# Altium schematic sheet TestShieldICs.SchDoc
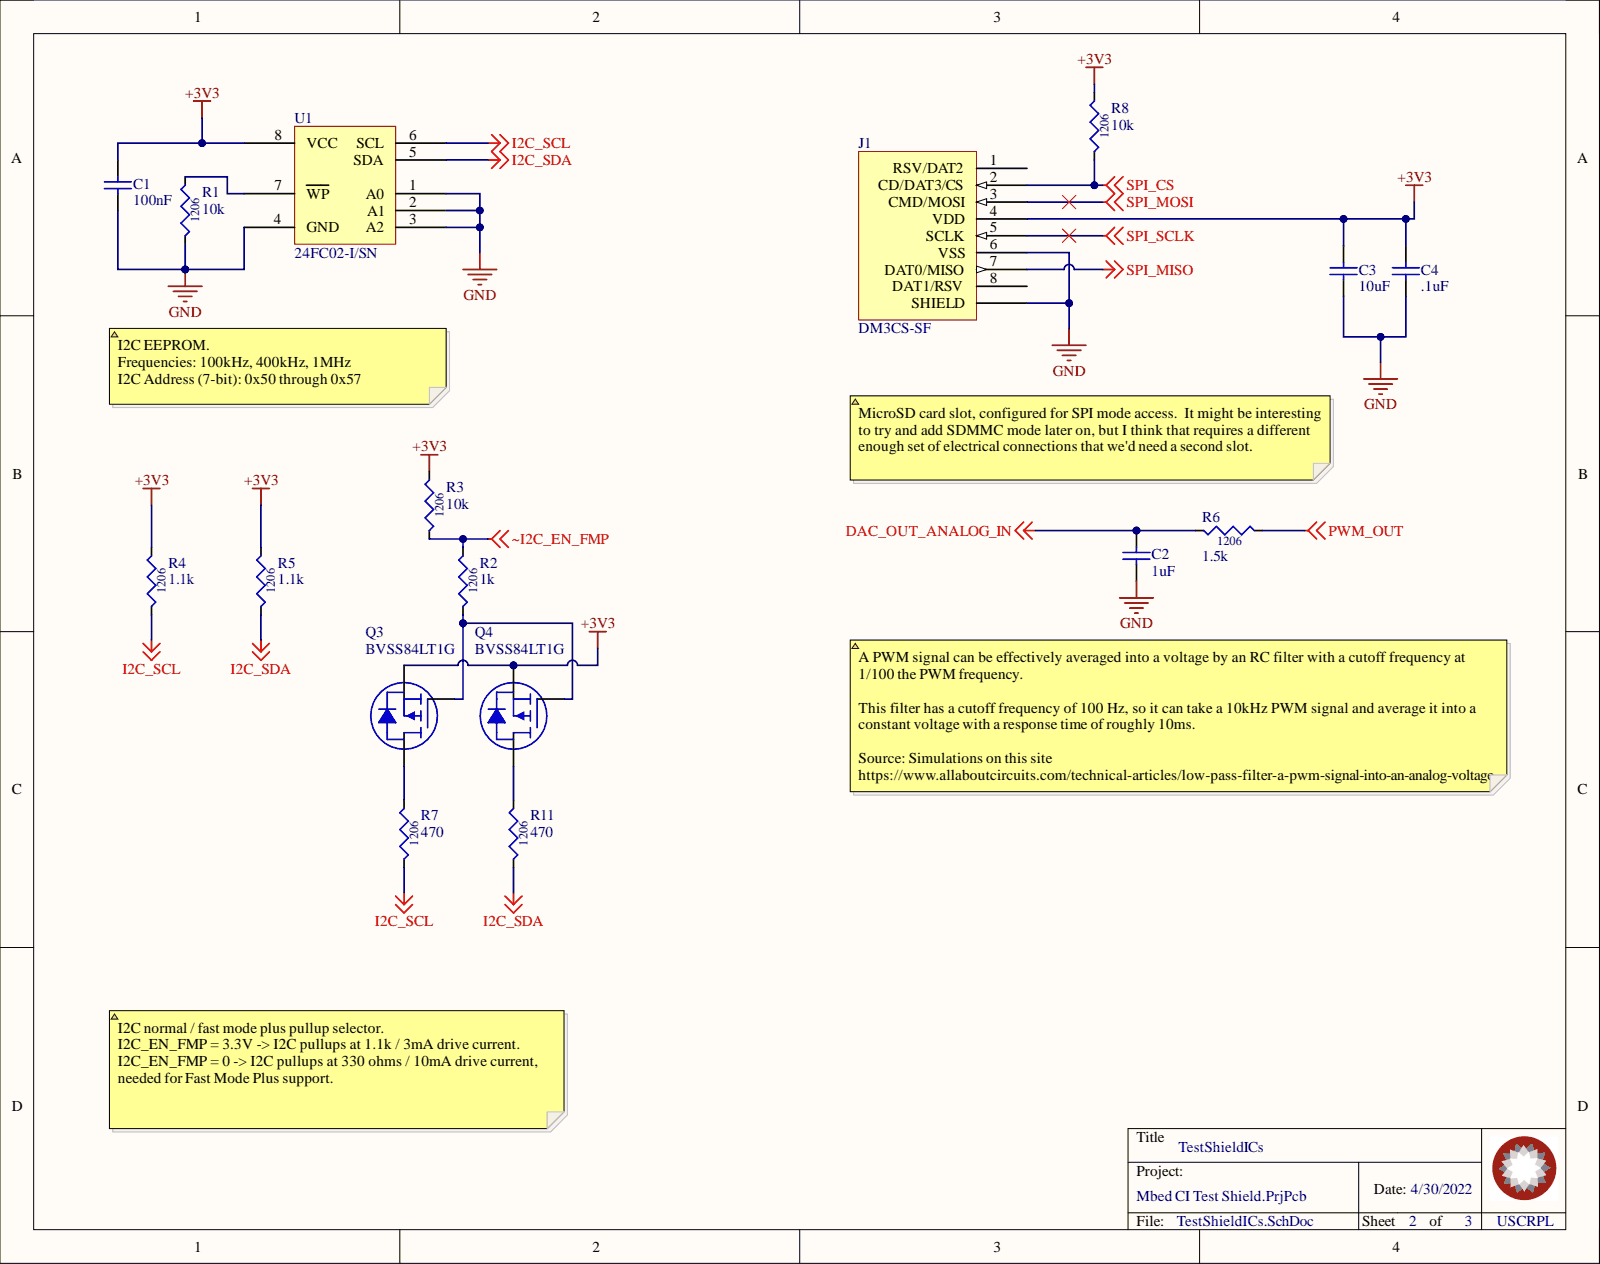
[diagram p0: TestShieldICs.SchDoc]

## Parts
C1  100nF  [C1206]
C2  1uF  [C1206]
C3  10uF  [C1206]
C4  .1uF  [C1206]
J1  DM3CS-SF  [DM3CS-SF]
Q3  BVSS84LT1G  [ON SEMI SOT-23-3]
Q4  BVSS84LT1G  [ON SEMI SOT-23-3]
R1  10k  [R1206]
R2  1k  [R1206]
R3  10k  [R1206]
R4  1.1k  [R1206]
R5  1.1k  [R1206]
R6  1.5k  [R1206]
R7  470  [R1206]
R8  10k  [R1206]
R11  470  [R1206]
U1  24FC02-I/SN  [MICROCHIP SOIC-8 150MIL WIDE]

## Nets
+3V3: C1.2 C3.2 C4.2 J1.4(VDD) Q3.2 Q4.2 R3.2 R4.2 R5.2 R8.2 U1.8(VCC)
DAC_OUT_ANALOG_IN: C2.2 R6.1
GND: C1.1 C2.1 C3.1 C4.1 J1.6(VSS) J1.M(SHIELD) R1.1 U1.1(A0) U1.2(A1) U1.3(A2) U1.4(GND)
I2C_SCL: R4.1 R7.1 U1.6(SCL)
I2C_SDA: R5.1 R11.1 U1.5(SDA)
PWM_OUT: R6.2
SPI_CS: J1.2(CD/DAT3/CS) R8.1
SPI_MISO: J1.7(DAT0/MISO)
SPI_MOSI: J1.3(CMD/MOSI)
SPI_SCLK: J1.5(SCLK)
~I2C_EN_FMP: R2.2 R3.1
NetQ3_1: Q3.1 Q4.1 R2.1
NetQ3_3: Q3.3 R7.2
NetQ4_3: Q4.3 R11.2
NetR1_2: R1.2 U1.7(WP)

Not connected: J1 1(RSV/DAT2) 8(DAT1/RSV)

## Library data of the parts
C1 = CMP-054-000057-2: CER 100nF 100V X7R 1206 1mOhm; Component Kind: Standard; Design Item ID: GRM319R72A104KA01D; Source: Capacitors.SchLib; Supplier 1: Digi-Key; Supplier Part Number 1: 490-3364-1-ND; Library Name: USCRPL
C2 = CMP-054-000013-3: CER 1UF 50V X7R 1206; Made by: Brennan Swanton; Reviewed by: Carter Allen; Component Kind: Standard; Design Item ID: CL31B105KBHNNNE; Source: Capacitors.SchLib; Supplier 1: Digi-Key; Supplier Part Number 1: 399-C1206C105K5RAC7800CT-ND; Library Name: USCRPL
C3 = CL31B106KLHNNNE: CER 10UF 35V X7R 1206; Made By: Brennan Swanton; Reviewed By: Carter Allen; Component Kind: Standard; Library Name: Capacitors.SchLib; Supplier 1: Digi-Key; Supplier Part Number 1: 587-3007-1-ND
C4 = CL31B104KBCNNNC: CAP CER 0.1UF 50V C0G/NP0 1206; Made By: Brennan Swanton; Reviewed By: Carter Allen; Component Kind: Standard; Library Name: Capacitors.SchLib; Supplier 1: Digi-Key; Supplier Part Number 1: 490-8335-1-ND
J1 = CMP-021-000009-2: microSD™ Card Hinge Connector (SPI Bus Mode); Made By: Diego Gomez Ruiz; Reviewed By: Jamie Smith; Component Kind: Standard; Design Item ID: DM3CS-SF; Source: Connectors.SchLib; Supplier 1: Digi-Key; Supplier Part Number 1: HR1972CT-ND; Library Name: USCRPL
Q3 Q4 = BVSS84LT1G: MOSFET P-CH 50V 130MA SOT-23-3; Manufacturer: ON Semiconductor; Current - Continuous Drain (Id) @ 25°C: 130mA (Ta); Database Table Name: MOSFET - Single - P-Ch; Drive Voltage (Max Rds On,  Min Rds On): 5V; FET Type: P-Channel; Gate Charge (Qg) (Max) @ Vgs: 2.2nC @ 10V; Input Capacitance (Ciss) (Max) @ Vds: 36pF @ 5V; Power Dissipation (Max): 225mW (Ta); Rds On (Max) @ Id, Vgs: 10Ohm @ 100mA, 5V; Vgs (Max): ±20V; Vgs(th) (Max) @ Id: 2V @ 250µA; Component Kind: Standard; ComponentLink1Description: Datasheet; ComponentLink1URL: http://www.onsemi.com/pub/Collateral/BSS84LT1-D.PDF; ComponentLink2Description: DigiKey Link; ComponentLink2URL: http://digikey.com/product-detail/en/on-semiconductor/BVSS84LT1G/BVSS84LT1GOSCT-ND/5213198; Drain to Source Voltage (Vdss): 50V; LastUpdated: 4/12/2022 11:00:56 PM; Library Name: Celestial Altium Library - altium_library.DbLib; Lifecycle Status: Active; Minimum Order: 1; Mounting Type: Surface Mount; Operating Temperature: -55°C ~ 150°C (TJ); Package / Case: TO-236-3, SC-59, SOT-23-3; Packaging: TapeAndReel; Part Number: BVSS84LT1G; Part Status: Active; Price: 0.07; Series: -; Supplier 1: DigiKey; Supplier Device Package: SOT-23-3; Supplier Part Number 1: BVSS84LT1GOSCT-ND; Technology: MOSFET (Metal Oxide)
R1 R3 R8 = CMP-024-000034-3: 10K OHM 1% 1/4W 1206; Made By: Brennan Swanton; Reviewed By: Carter Allen; Component Kind: Standard; Design Item ID: ERJ-8ENF1002V; Source: Resistors.SchLib; Supplier 1: Digi-Key; Supplier Part Number 1: 541-2247-1-ND; Library Name: USCRPL
R2 = CMP-024-000033-4: 1K OHM 1% 1/4W 1206; Made By: Brennan Swanton; Reviewed By: Carter Allen; Component Kind: Standard; Design Item ID: ERJ-8ENF1001V; Source: Resistors.SchLib; Supplier 1: Digi-Key; Supplier Part Number 1: P1.00KFCT-ND; Library Name: USCRPL
R4 R5 = CMP-024-000039-4: 1.1K OHM 1% 1/4W 1206; Made By: Brennan Swanton; Reviewed By: Carter Allen; Component Kind: Standard; Design Item ID: ERJ-8ENF1101V; Source: Resistors.SchLib; Supplier 1: Digi-Key; Supplier Part Number 1: P1.10KFCT-ND; Library Name: USCRPL
R6 = CMP-024-000095-5: RES 1.5K OHM 1% 1/4W 1206; Made By: Rita Yang; Component Kind: Standard; Design Item ID: RMCF1206FT1K50; Source: Resistors.SchLib; Supplier 1: Digi-Key; Supplier Part Number 1: RMCF1206FT1K50CT-ND; Library Name: USCRPL
R7 R11 = CMP-024-000067-4: 470 OHM 1% 1/4W 1206; Made By: Jamie Smith; Component Kind: Standard; Design Item ID: ERJ-8ENF4700V; Source: Resistors.SchLib; Supplier 1: Digi-Key; Supplier Part Number 1: P470FCT-ND; Library Name: USCRPL
U1 = 24FC02-I/SN: IC EEPROM 2KBIT I2C 1MHZ 8SOIC; Manufacturer: Microchip Technology; Access Time: 450 ns; Database Table Name: Memory; Memory Interface: I²C; Memory Size: 2Kb (256 x 8); Memory Type: Non-Volatile; Voltage - Supply: 1.7V ~ 5.5V; Write Cycle Time - Word, Page: 5ms; Clock Frequency: 1 MHz; Component Kind: Standard; ComponentLink1Description: Datasheet; ComponentLink1URL: http://www.microchip.com/mymicrochip/filehandler.aspx?ddocname=en011845; ComponentLink2Description: DigiKey Link; ComponentLink2URL: https://www.digikey.com/en/products/detail/microchip-technology/24FC02-I-SN/9867254; LastUpdated: 4/12/2022 10:55:25 PM; Library Name: Celestial Altium Library - altium_library.DbLib; Lifecycle Status: Active; Memory Format: EEPROM; Minimum Order: 1; Mounting Type: Surface Mount; Operating Temperature: -40°C ~ 85°C (TA); Package / Case: 8-SOIC (0.154", 3.90mm Width); Packaging: Tube; Part Number: 24FC02-I/SN; Part Status: Active; PartId: 1062; Price: 0.21; Series: -; Supplier 1: DigiKey; Supplier Device Package: 8-SOIC; Supplier Part Number 1: 24FC02-I/SN-ND; Technology: EEPROM
